# Altium schematic sheet POWER INPUT.SchDoc("POWER IN")
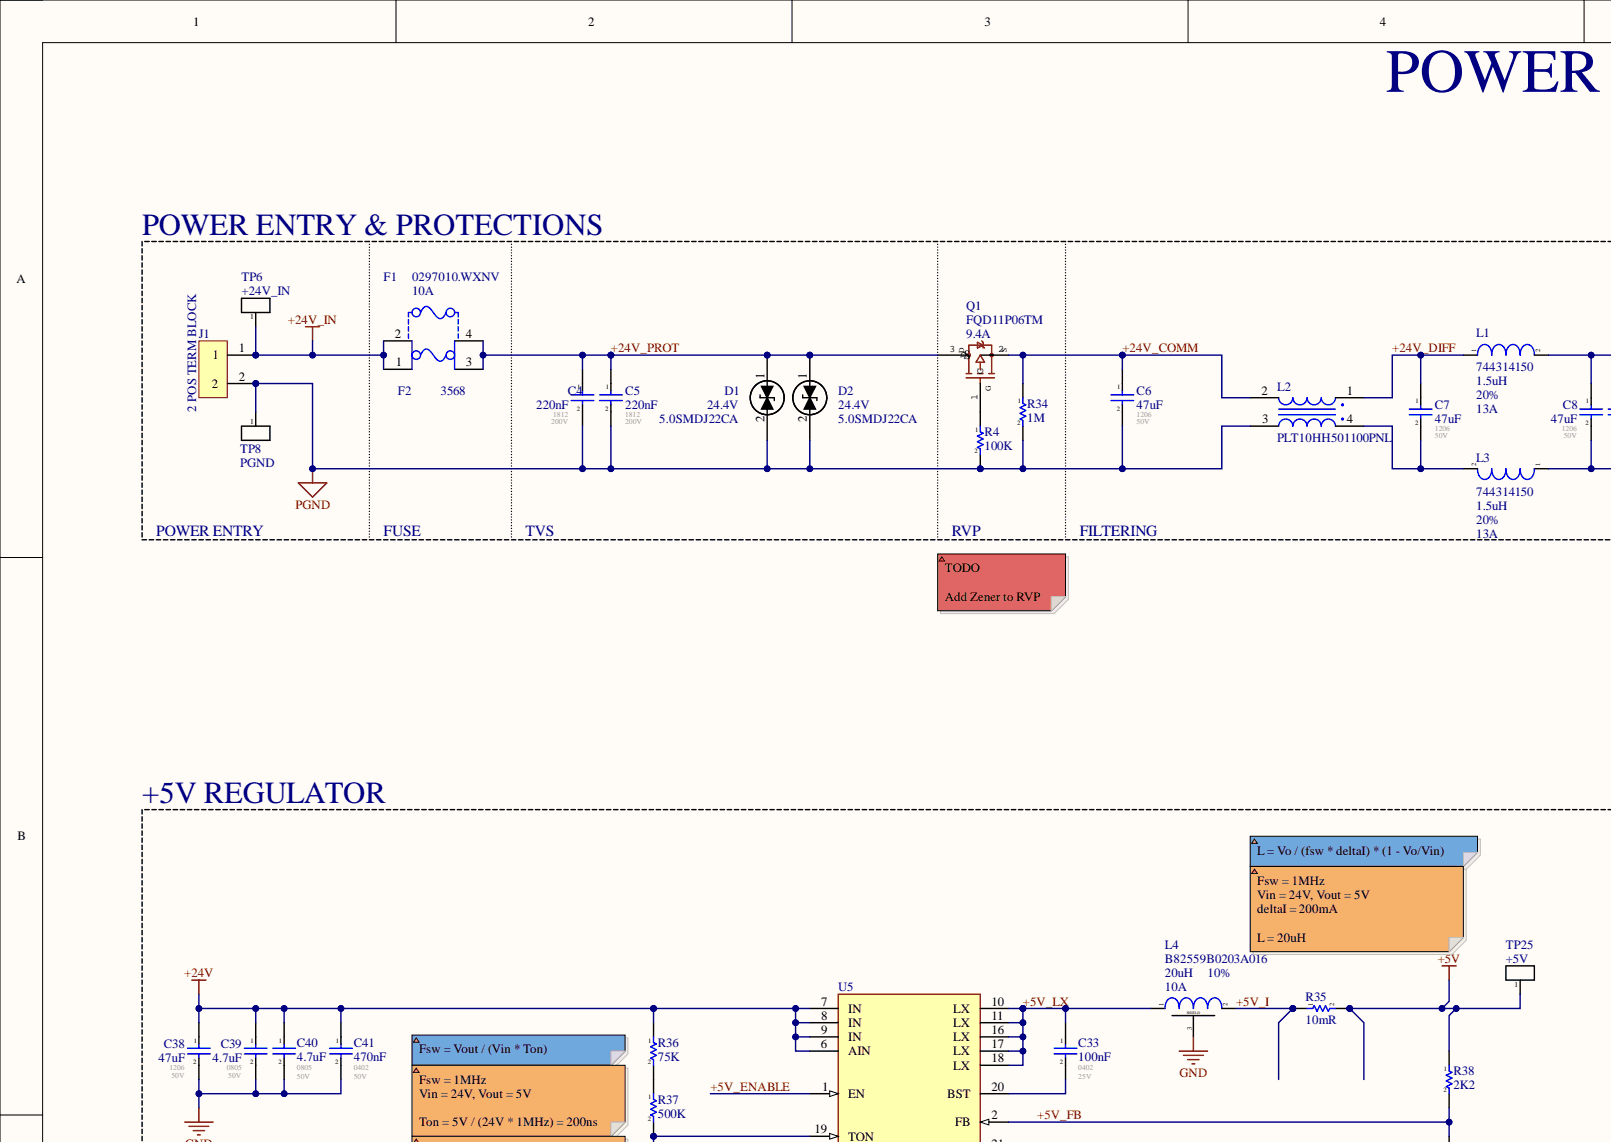
[diagram p0: POWER INPUT.SchDoc, top left]
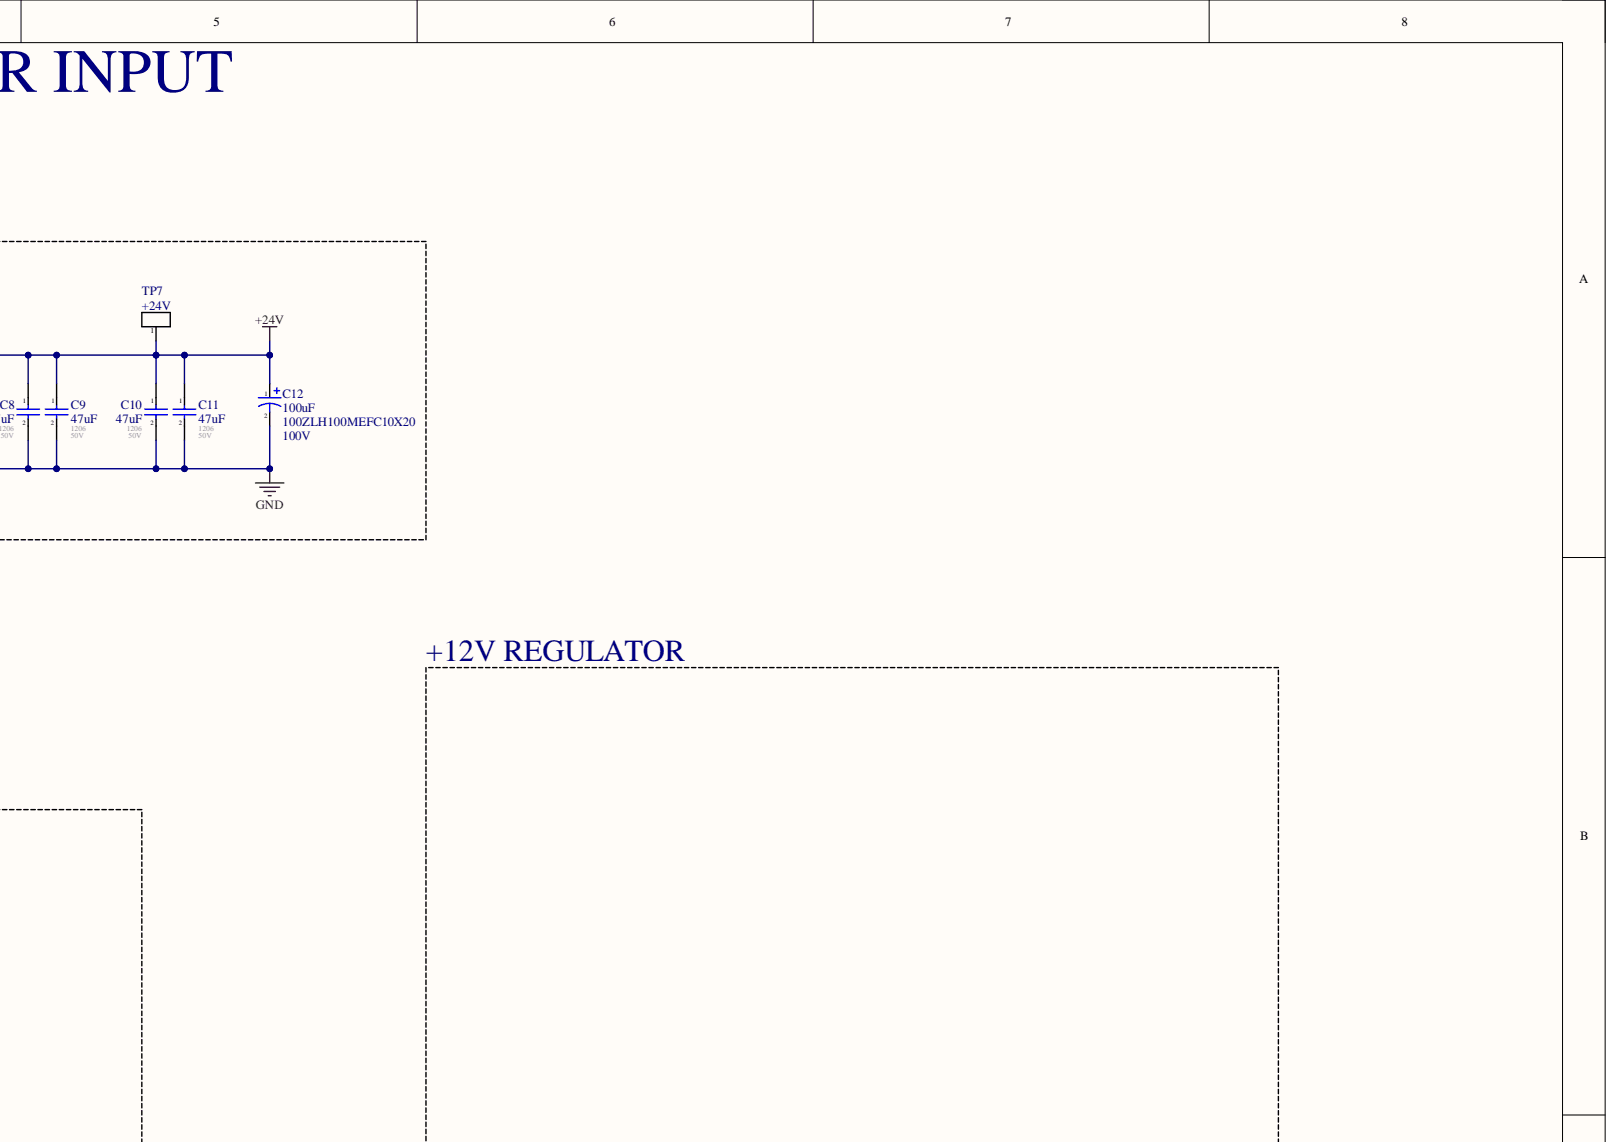
[diagram p1: POWER INPUT.SchDoc, top right]
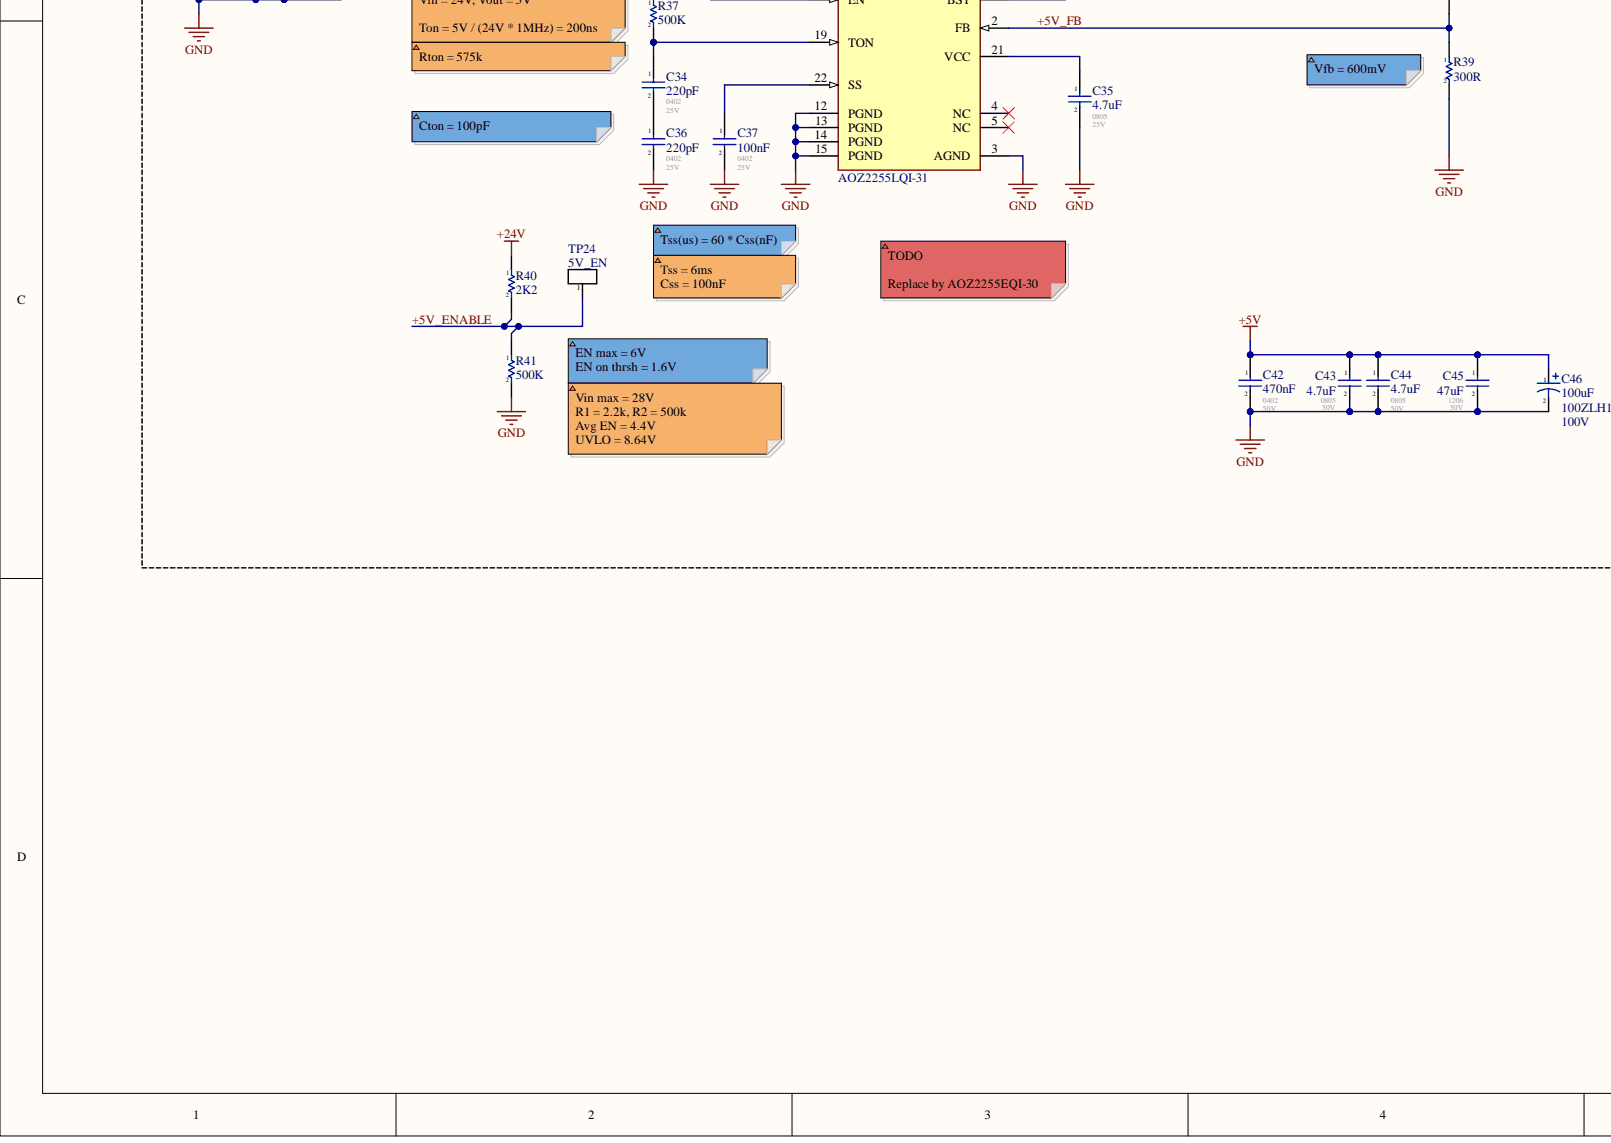
[diagram p2: POWER INPUT.SchDoc, bottom left]
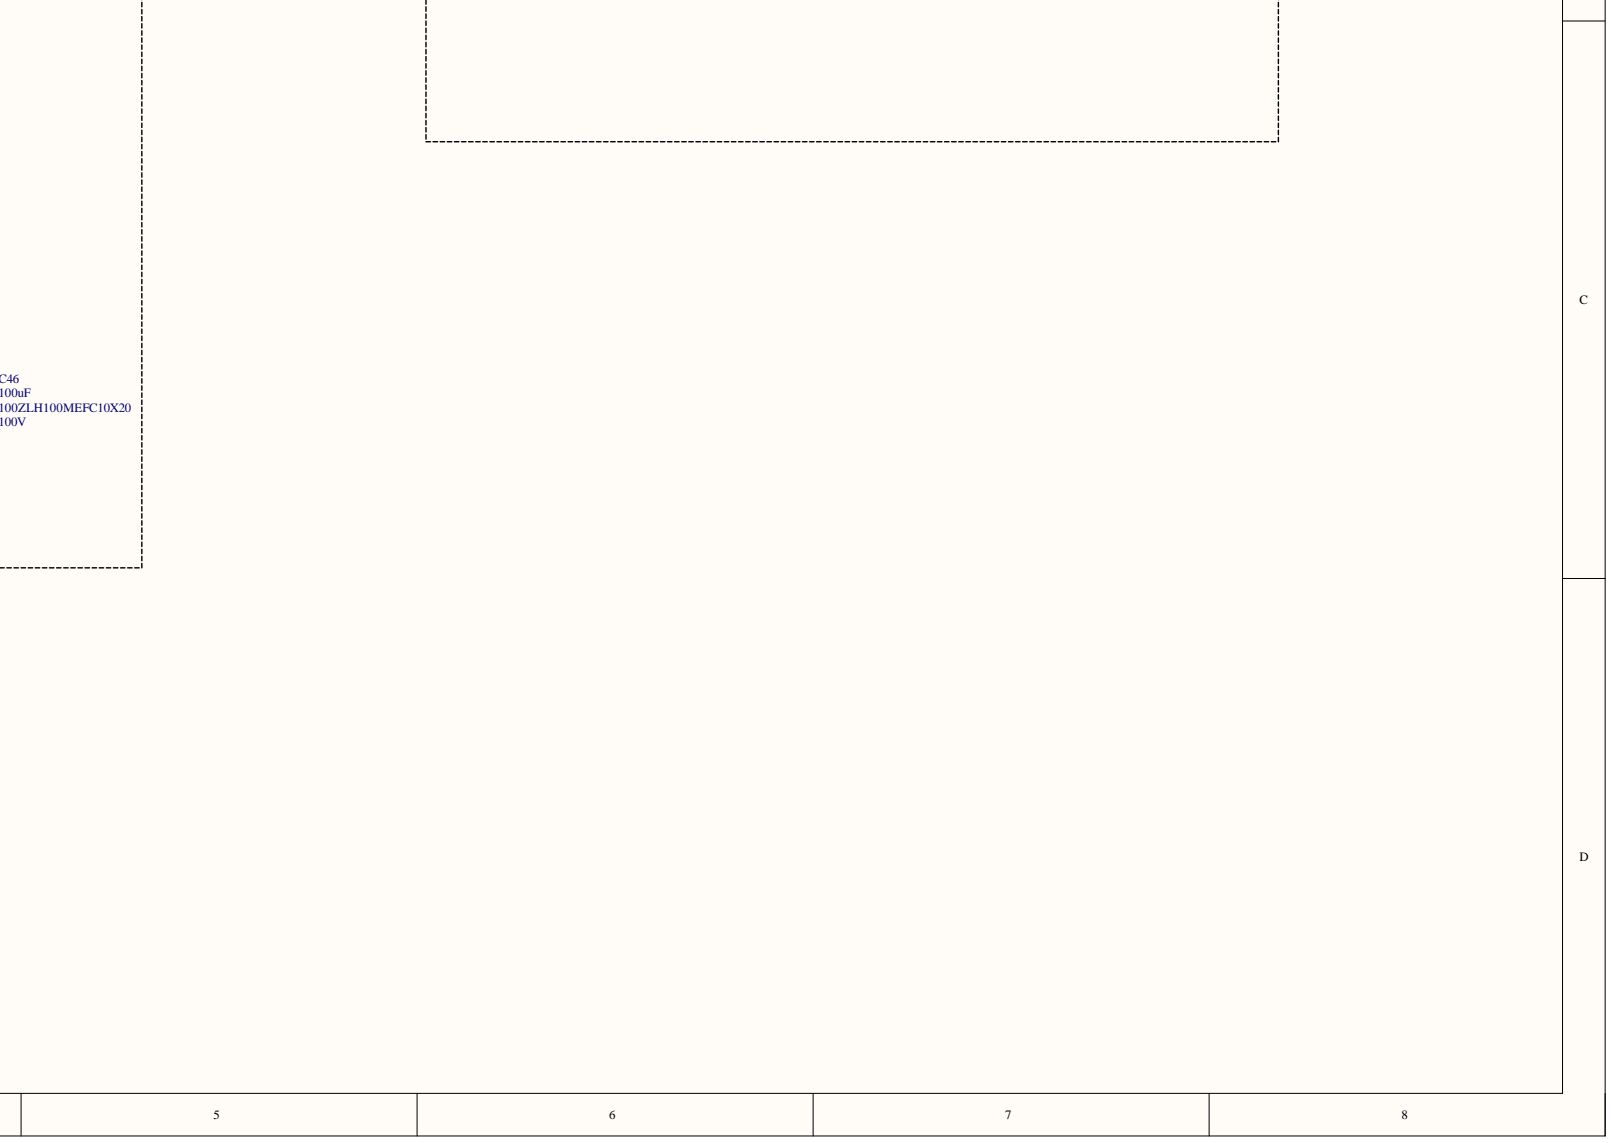
[diagram p3: POWER INPUT.SchDoc, bottom right]

## Parts
C4  220nF  Voltage - Rated: 200V  [1812]
C5  220nF  Voltage - Rated: 200V  [1812]
C6  47uF  Voltage - Rated: 50V  [1206]
C7  47uF  Voltage - Rated: 50V  [1206]
C8  47uF  Voltage - Rated: 50V  [1206]
C9  47uF  Voltage - Rated: 50V  [1206]
C10  47uF  Voltage - Rated: 50V  [1206]
C11  47uF  Voltage - Rated: 50V  [1206]
C12  100uF  Rated Voltage: 100V  [100ZLH100MEFC10X20]
C33  100nF  Voltage - Rated: 25V  [0402]
C34  220pF  Voltage - Rated: 25V  [0402]
C35  4.7uF  Voltage - Rated: 25V  [0805]
C36  220pF  Voltage - Rated: 25V  [0402]
C37  100nF  Voltage - Rated: 25V  [0402]
C38  47uF  Voltage - Rated: 50V  [1206]
C39  4.7uF  Voltage - Rated: 50V  [0805]
C40  4.7uF  Voltage - Rated: 50V  [0805]
C41  470nF  Voltage - Rated: 50V  [0402]
C42  470nF  Voltage - Rated: 50V  [0402]
C43  4.7uF  Voltage - Rated: 50V  [0805]
C44  4.7uF  Voltage - Rated: 50V  [0805]
C45  47uF  Voltage - Rated: 50V  [1206]
C46  100uF  Rated Voltage: 100V  [100ZLH100MEFC10X20]
D1  5.0SMDJ22CA  Voltage - Breakdown: 24.4V  [DO214AB (SMC)]
D2  5.0SMDJ22CA  Voltage - Breakdown: 24.4V  [DO214AB (SMC)]
F1  0297010.WXNV  Current - Hold: 10A  [0297010.WXNV]
F2  3568  [3568]
J1  2 POS TERM BLOCK  [TERM-2_90_100MIL]
L1  744314150  Inductance: 1.5uH  Tolerance: 20%  [744314150]
L2  PLT10HH501100PNL  [PLT10HH501100PNL]
L3  744314150  Current Rating: 13A  Inductance: 1.5uH  Tolerance: 20%  [744314150]
L4  B82559B0203A016  Current Rating: 10A  Inductance: 20uH  Tolerance: 10%  [B82559B0203A016]
Q1  FQD11P06TM  Current: 9.4A  [FQD11P06TM]
R4  100K  [RES_0603]
R34  1M  [RES_0402]
R35  10mR  [RES_2512]
R36  75K  [RES_0402]
R37  500K  [RES_0402]
R38  2K2  [RES_0603]
R39  300R  [RES_0603]
R40  2K2  [RES_0603]
R41  500K  [RES_0402]
TP6  +24V_IN  [TP_HOLE]  (no BOM)
TP7  +24V  [TP_HOLE]  (no BOM)
TP8  PGND  [TP_HOLE]  (no BOM)
TP24  5V_EN  [TP_PAD_SMALL]  (no BOM)
TP25  +5V  [TP_HOLE]  (no BOM)
U5  AOZ2255LQI-31  [AOZ2255LQI-31]

## Nets
+5V: C42.1 C43.1 C44.1 C45.1 C46.1 R35.2 R38.1 TP25.1
+24V: C8.1 C9.1 C10.1 C11.1 C12.1 C38.1 C39.1 C40.1 C41.1 L1.2 R36.1 R40.1 TP7.1 U5.6(AIN) U5.7(IN) U5.8(IN) U5.9(IN)
+24V_IN: F2.1 F2.2 J1.1 TP6.1
GND: C8.2 C9.2 C10.2 C11.2 C12.2 C35.2 C36.2 C37.2 C38.2 C39.2 C40.2 C41.2 C42.2 C43.2 C44.2 C45.2 C46.2 L3.1 L4.3 R39.2 R41.2 U5.3(AGND) U5.12(PGND) U5.13(PGND) U5.14(PGND) U5.15(PGND)
PGND: C4.2 C5.2 C6.2 D1.2 D2.2 J1.2 L2.3 R4.2 R34.2 TP8.1
+5V_ENABLE_5: R40.2 R41.1 TP24.1 U5.1(EN)  [also labelled +5V_ENABLE]
+5V_FB_5: R38.2 R39.1 U5.2(FB)  [also labelled +5V_FB]
+5V_I_5: L4.2 R35.1  [also labelled +5V_I]
+5V_LX_5: C33.1 L4.1 U5.10(LX) U5.11(LX) U5.16(LX) U5.17(LX) U5.18(LX)  [also labelled +5V_LX]
+24V_COMM_5: C6.1 L2.2 Q1.2 R34.1  [also labelled +24V_COMM]
+24V_DIFF_5: C7.1 L1.1 L2.1  [also labelled +24V_DIFF]
+24V_PROT_5: C4.1 C5.1 D1.1 D2.1 F2.3 F2.4 Q1.3  [also labelled +24V_PROT]
NetC7_2: C7.2 L2.4 L3.2
NetC33_2: C33.2 U5.20(BST)
NetC34_1: C34.1 R37.2 U5.19(TON)
NetC34_2: C34.2 C36.1
NetC35_1: C35.1 U5.21(VCC)
NetC37_1: C37.1 U5.22(SS)
NetQ1_1: Q1.1 R4.1
NetR36_2: R36.2 R37.1

Not connected: U5 4(NC) 5(NC)

## Library data of the parts
C4-C11 C33-C45 = CAP_CER: Generic SMT Ceramic Capacitor; Component Kind: Standard; ComponentLink1Description: Digikey; ComponentLink1URL: [Digikey Link]; ComponentLink2Description: Datasheet; ComponentLink2URL: [Datasheet Link]; Library Name: Symbols.SchLib
C12 C46 = CAP_100ZLH100MEFC10X20: CAP 100uF 20% 100V T/H; Mfr: Rubycon; Impedance: 120mR; Component Kind: Standard; ComponentLink1Description: Digikey; ComponentLink1URL: https://www.digikey.ca/en/products/detail/rubycon/100ZLH100MEFC10X20/3563824; ComponentLink2Description: Mouser; ComponentLink2URL: https://www.mouser.ca/ProductDetail/Rubycon/100ZLH100MEFC10X20; ComponentLink3Description: Datasheet; ComponentLink3URL: https://www.rubycon.co.jp/wp-content/uploads/catalog-aluminum/ZLH.pdf; ComponentLink4Description: Octoparts; ComponentLink4URL: https://octopart.com/100zlh100mefc10x20-rubycon-19941711; Digikey Part Number: 1189-1887-ND; Height: 22mm; Manufacturer Part Number: 100ZLH100MEFC10X20; Operating Temperature: -40C - 105C; Tolerance: 20%; Created By: Pascal-Emmanuel Lachance; Library Name: CryptLib.SchLib
D1 D2 = DIODE_5.0SMDJ22CA: TVS Diode 24.4V; Mfr: Littelfuse; Current: 141A Peak; Voltage - Reverse: 22V; Component Kind: Standard; ComponentLink1Description: Datasheet; ComponentLink1URL: https://www.littelfuse.com/~/media/electronics/datasheets/tvs_diodes/littelfuse_tvs_diode_5_0smdj_datasheet.pdf.pdf; ComponentLink2Description: Mfr page; ComponentLink2URL: https://www.littelfuse.com/products/tvs-diodes/surface-mount/5_0smdj/5_0smdj22ca.aspx; ComponentLink3Description: Digikey; ComponentLink3URL: https://www.digikey.ca/en/products/detail/littelfuse-inc/5-0SMDJ22CA/2024320; ComponentLink4Description: Mouser; ComponentLink4URL: https://www.mouser.ca/ProductDetail/Littelfuse/50SMDJ22CA; Operating Temperature: -65C - 150C; Package / Case: SMC; Supplier 1: Digi-Key; Supplier 2: Mouser; Supplier Part Number 1: F10850CT-ND; Supplier Part Number 2: 652-5.0SMDJ22CA; Created By: Pascal-Emmanuel Lachance; Library Name: CryptLib.SchLib
F1 = FUSE_0297010.WXNV: FUSE AUTO 10A 32V BLADE MINI; Mfr: Littelfuse; Current - Max: 40A; Resistance: 7.42mR; Rule: Component Clearance Constraint [Horizontal Clearance = -1mil    Vertical Clearance = 10mil]; Time to Trip: 100ms; Component Kind: Standard; ComponentLink1Description: Datasheet; ComponentLink1URL: https://www.littelfuse.com/~/media/automotive/datasheets/fuses/passenger-car-and-commercial-vehicle/blade-fuses/littelfuse_mini_datasheet.pdf; ComponentLink2Description: Digikey; ComponentLink2URL: https://www.digikey.ca/en/products/detail/littelfuse-inc/0297010-WXNV/146591; ComponentLink3Description: Mouser; ComponentLink3URL: https://www.mouser.ca/ProductDetail/Littelfuse/0297010WXNV; ComponentLink4Description: Octopart; ComponentLink4URL: https://octopart.com/0297010.wxnv-littelfuse-566872; Current - Trip: 10A; Operating Temperature: -40C - 125C; Package / Case: BLADE MINI AUTO; Voltage Rating: 32V; Created By: Pascal-Emmanuel Lachance; Library Name: CryptLib.SchLib
F2 = FUSE_HOLDER_3568: FUSE HOLDER BLADE; Mfr: Keystone; Current - Max: 30A; Voltage Rating: 500V; Component Kind: Standard; Operating Temperature: -50C - 145C; Created By: Pascal-Emmanuel Lachance; Library Name: CryptLib.SchLib
J1 = TERM_BLOCK-2: Component Kind: Standard; Library Name: Connectors.SchLib
L1 L3 = IND_744314150: FIXED IND 1.5UH 13A 4.3 MOHM SMD; Mfr: Wurth; Resistance: 4.3mR; Component Kind: Standard; ComponentLink1Description: Digikey; ComponentLink1URL: https://www.digikey.ca/en/products/detail/w%C3%BCrth-elektronik/744314150/1638563; ComponentLink2Description: Mouser; ComponentLink2URL: https://www.mouser.ca/ProductDetail/Wurth-Elektronik/744314150; ComponentLink3Description: Datasheet; ComponentLink3URL: https://www.we-online.com/katalog/datasheet/744314150.pdf; Operating Temperature: -40C - 150C; Created By: Pascal-Emmanuel Lachance; Library Name: CryptLib.SchLib
  L1: Current Rating: 13A
L2 = IND_PLT10HH501100PNL: 2 Line Common Mode Choke Surface Mount 500 Ohms @ 10MHz 10A DCR 4.1mOhm; mfr: Murata; DC Resistance: 4.1mR; Impedance @ 10MHz: 500R; Rating: Automotive (AEC-Q200); Voltage Rating: 100V; Component Kind: Standard; ComponentLink1Description: Datasheet; ComponentLink1URL: https://www.murata.com/en-eu/products/productdata/8796754935838/QFLB9101.pdf; ComponentLink2Description: Mfr page; ComponentLink2URL: https://www.murata.com/en-us/products/productdetail?partno=PLT10HH501100PN%23; ComponentLink3Description: Digikey; ComponentLink3URL: https://www.digikey.ca/en/products/detail/murata-electronics/PLT10HH501100PNL/6196056; ComponentLink4Description: Mouser; ComponentLink4URL: https://www.mouser.ca/ProductDetail/Murata-Electronics/PLT10HH501100PNL; Current Rating: 10A; Operating Temperature: -55C - 105C; Created By: Pascal-Emmanuel Lachance; Library Name: CryptLib.SchLib
L4 = IND_B82559B0203A016: 20uH Shielded 10A Inductor; Mfr: TDK; Material: Ferrite; Resistance: 12.1mR; Component Kind: Standard; ComponentLink1Description: Datasheet; ComponentLink1URL: https://media.digikey.com/pdf/Data%20Sheets/TDK%20PDFs/B82559BxA016_Series_DS.pdf; ComponentLink2Description: Digikey; ComponentLink2URL: https://www.digikey.ca/en/products/detail/epcos-tdk-electronics/B82559B0203A016/13165560; ComponentLink3Description: Mouser; ComponentLink3URL: https://www.mouser.ca/ProductDetail/EPCOS-TDK/B82559B0203A016; ComponentLink4Description: Mfr page; ComponentLink4URL: https://product.tdk.com/en/search/inductor/inductor/smd/info?part_no=B82559B0203A016; ComponentLink5Description: Octoparts; ComponentLink5URL: https://octopart.com/b82559b0203a016-epcos-111982021; Operating Temperature: -40C to 150C; Rating: AEC-Q200; Supplier 1: Digi-Key; Supplier Part Number 1: 495-B82559B0203A016CT-ND; Created By: Pascal-Emmanuel Lachance; Library Name: CryptLib.SchLib
Q1 = TRANS_FQD11P06TM: MOSFET P-CH 60V 9.4A DPAK; Mfr: ON Semiconductor; Rds ON: 185mOhms; Vgs: +-30V; Component Kind: Standard; ComponentLink1Description: Digikey; ComponentLink1URL: https://www.digikey.ca/en/products/detail/on-semiconductor/FQD11P06TM/1054286; ComponentLink2Description: Mouser; ComponentLink2URL: https://www.mouser.ca/ProductDetail/ON-Semiconductor-Fairchild/FQD11P06TM; Digikey Part Number: FQD11P06TMCT-ND; Operating Temperature: -55C - 150C; Package / Case: DPAK; Vds: 60V; Library Name: CryptLib.SchLib
R4 R34 = RESISTOR: Power: 1/16W; Tolerance: 10%; Component Kind: Standard; ComponentLink1Description: Digikey; Description: Resistor; Library Name: Symbols.SchLib; Package / Case: 0603
R35 = RESISTOR: Power: 2W; Tolerance: 1%; Component Kind: Standard; ComponentLink1Description: Digikey; Description: Resistor; Library Name: Symbols.SchLib; Package / Case: 2512
R36 R37 R41 = RESISTOR: Power: 1/10W; Tolerance: 10%; Component Kind: Standard; ComponentLink1Description: Digikey; Description: Resistor; Library Name: Symbols.SchLib; Package / Case: 0402
R38 R40 = RESISTOR: Power: 1/16W; Tolerance: 2%; Component Kind: Standard; ComponentLink1Description: Digikey; Description: Resistor; Library Name: Symbols.SchLib; Package / Case: 0603
R39 = RESISTOR: Power: 1/8W; Tolerance: 2%; Component Kind: Standard; ComponentLink1Description: Digikey; Description: Resistor; Library Name: Symbols.SchLib; Package / Case: 0603
TP6-TP8 TP24 TP25 = TESTPOINT: Test Point; Library Name: Symbols.SchLib
U5 = IC_AOZ2255LQI-31: Buck Switching Regulator IC Positive Adjustable 0.6V 1 Output 12A 22-PowerVFQFN; Mfr: Alpha & Omega Semiconductor; Max Input Voltage: 28V; Max Output Voltage: 23.8V; Min Input Voltage: 6.5V; Component Kind: Standard; ComponentLink1Description: Digikey; ComponentLink1URL: https://www.digikey.ca/en/products/detail/alpha-omega-semiconductor-inc/AOZ2255LQI-31/12180202; ComponentLink2Description: Datasheet; ComponentLink2URL: http://aosmd.com/res/data_sheets/AOZ2255LQI-31.pdf; ComponentLink3Description: Octoparts; ComponentLink3URL: https://octopart.com/aoz2255lqi-31-alpha+%26+omega+semiconductor-109142852; Max Output Current: 12A; Min Output Voltage: 0.6V; Operating Temperature: -40C to 85C; Package: PowerVFQFN-22 (4x4); Supplier 1: Digi-Key; Supplier Part Number 1: 785-AOZ2255LQI-31TR-ND; Library Name: CryptLib.SchLib
